# Altium schematic sheet 05021002_Accelerometer.SchDoc(05021002_Accelerometer)
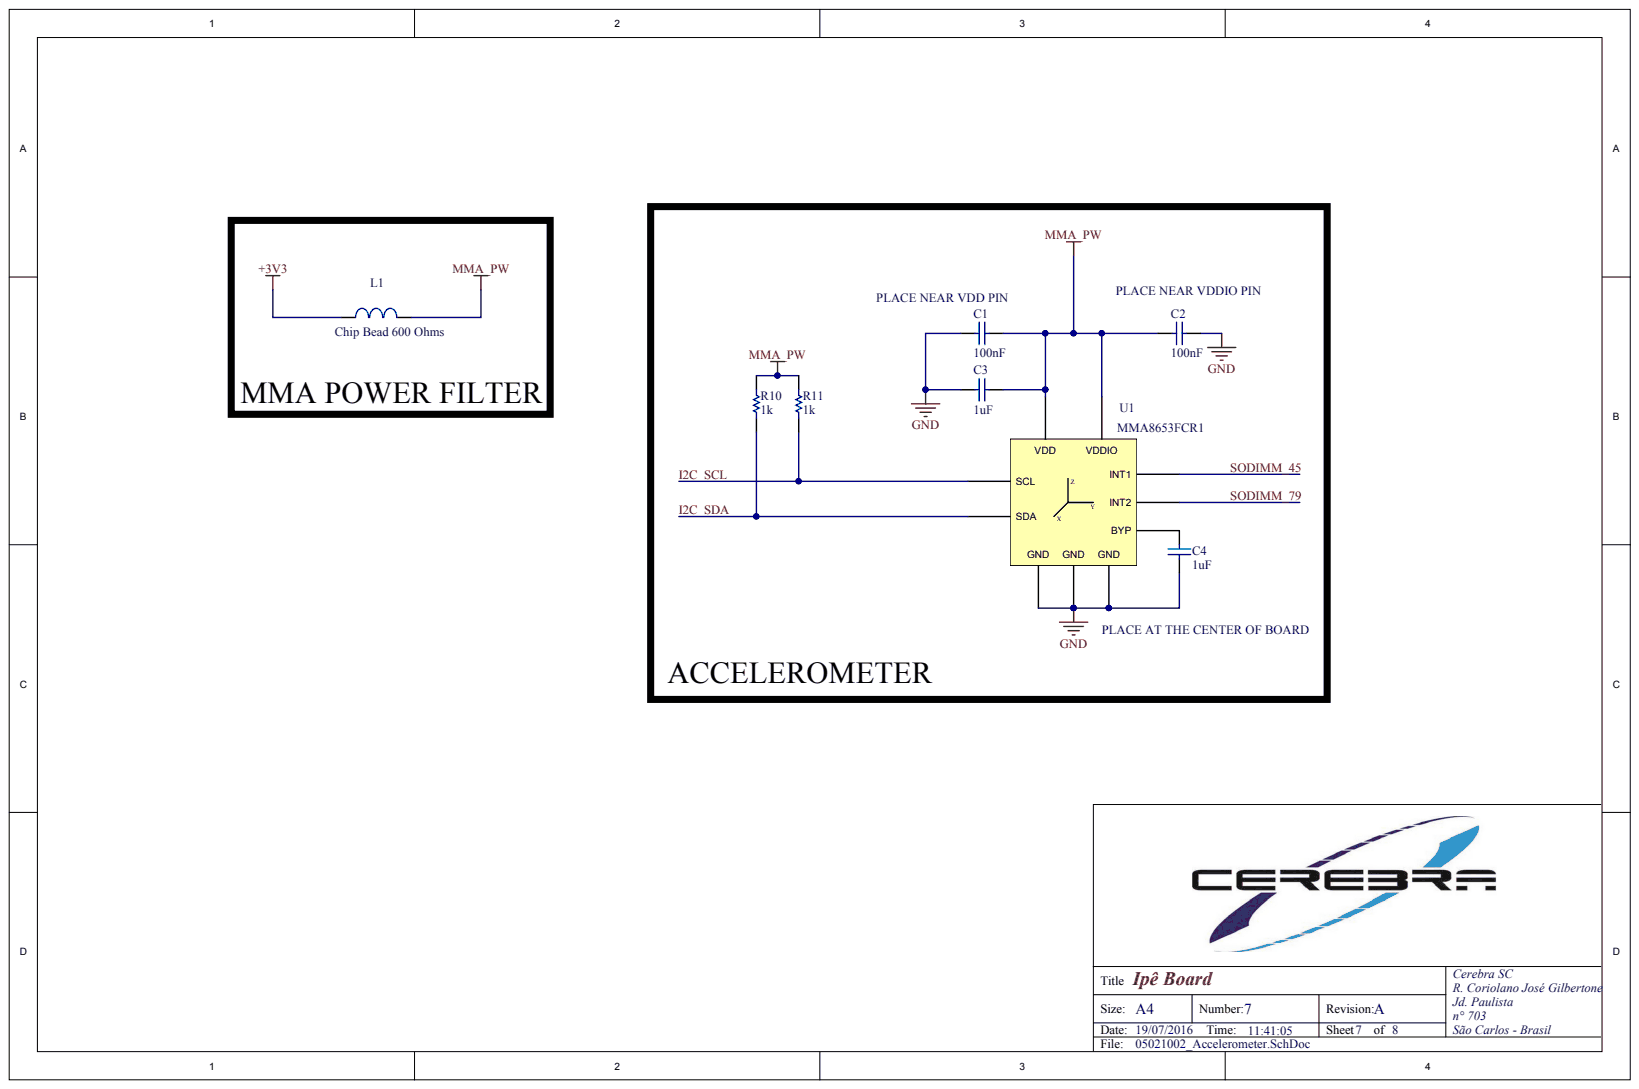
[diagram p0: 05021002_Accelerometer.SchDoc]

## Parts
C1  100nF  [CAPC2012X135N]
C2  100nF  [CAPC2012X135N]
C3  1uF  [CAPC2012X135N]
C4  1uF  [CAPC2012X135N]
L1  Chip Bead 600 Ohms  value 600 Ohms  [1608[0603]]
R10  1k  [0805]
R11  1k  [0805]
U1  MMA8653FCR1  [MMA8653F]

## Nets
+3V3: L1.1
GND: C1.1 C2.2 C3.1 C4.1 U1.6 U1.7 U1.9
MMA_PW: C1.2 C2.1 C3.2 L1.2 R10.2 R11.2 U1.1 U1.8
I2C_SCL: R11.1 U1.2(SCL)
I2C_SDA: R10.1 U1.10(SDA)
SODIMM_45: U1.3(INT1)
SODIMM_79: U1.5(INT2)
NetC4_2: C4.2 U1.4(BYP)

## Library data of the parts
C1 C2 = capacitor_gen: Generic Capacitor; Manufacturer 1: Wurth Electronics Inc; Part Number 1: 885012207016; Component Kind: Standard; Library Name: capacitor_smd_gen.SchLib; Value: 100nF
C3 C4 = capacitor_gen: Generic Capacitor; Manufacturer 1: AVX Corporation; Part Number 1: 0805ZC105KAT2A; Component Kind: Standard; Library Name: capacitor_smd_gen.SchLib; Value: 1uF
L1 = L: Wide Band Bead 600 Ohms - Rdc 0.45 mOhms 74279265; Manufacturer 1: Wurth Electronics Inc; Component Kind: Standard; Part Number 1: 742 792 65; Library Name: Eduardo.SchLib
R10 R11 = resistor_gen: Manufacturer 1: Yageo; Part Number 1: RC0805JR-071KL; Component Kind: Standard; Library Name: resistor_smd_gen.SchLib; Value: 1k
U1 = MMA8653FCR1: Manufacturer 1: Freescale Semiconductor - NXP; Component Kind: Standard; Part Number 1: MMA8653FCR1; Library Name: IPÊ_BOARD_LIBRARY.IntLib
